# Altium schematic sheet lcd&key.SchDoc(lcd&key)
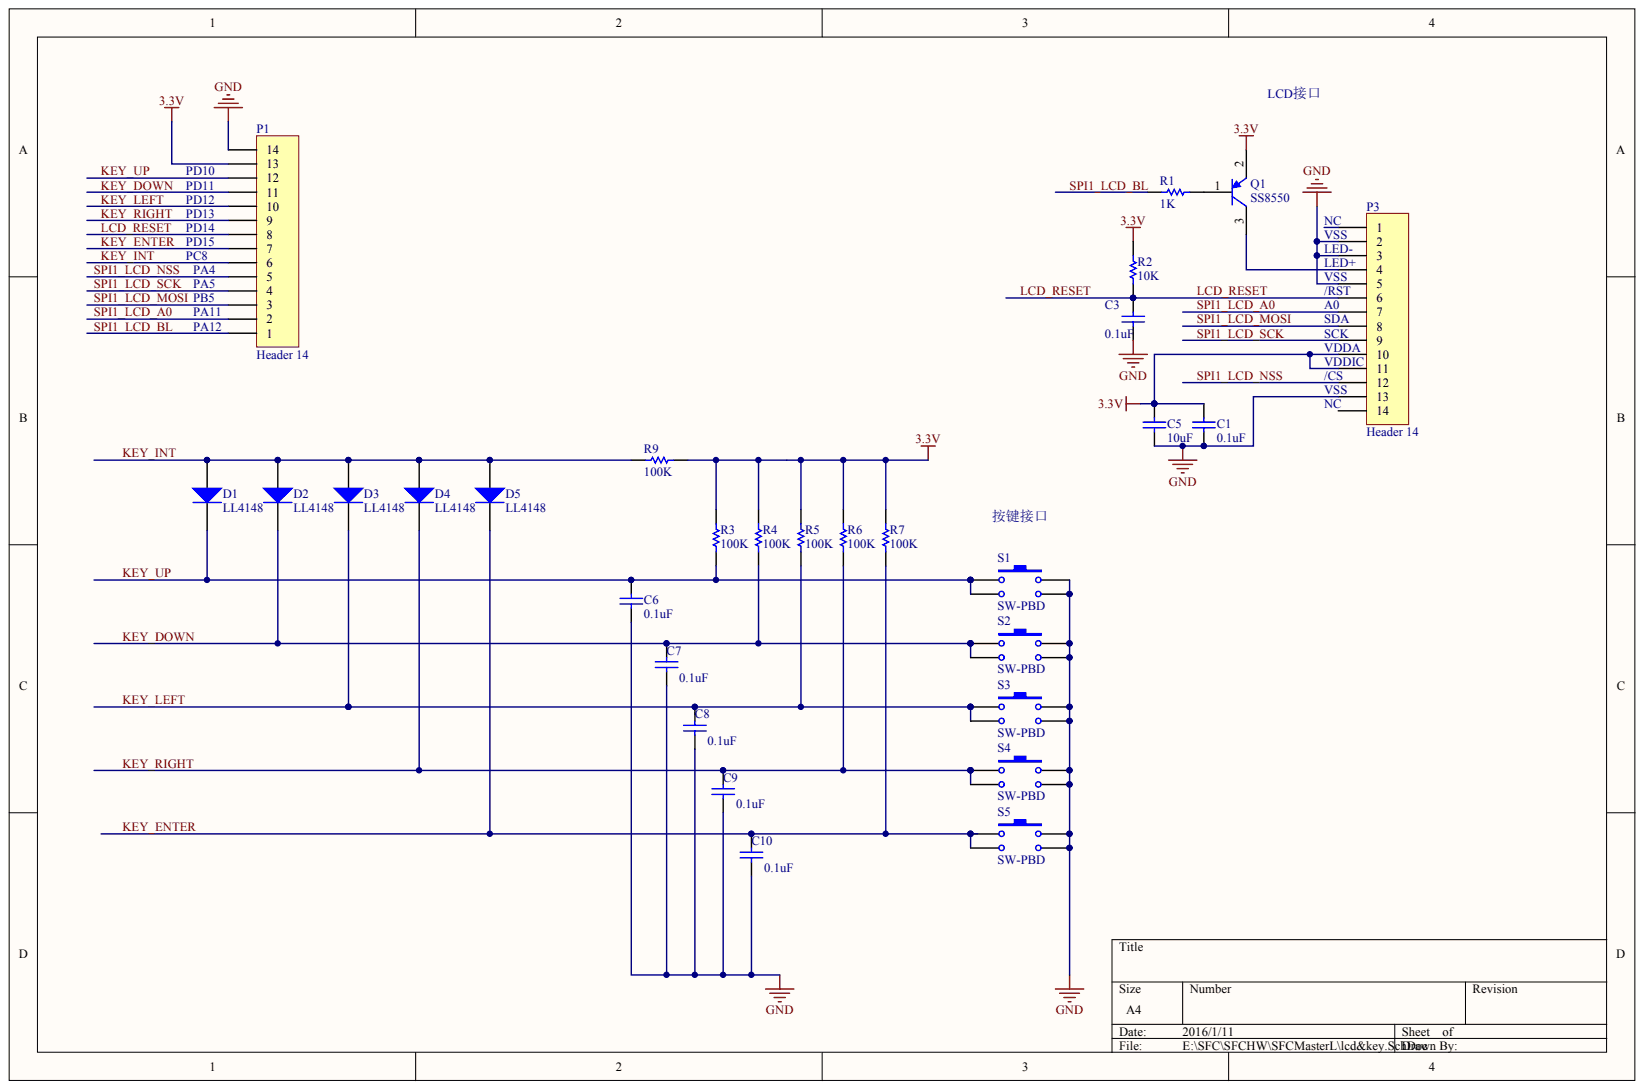
[diagram p0: lcd&key.SchDoc]

## Parts
C1  0.1uF
C3  0.1uF
C5  10uF
C6  0.1uF
C7  0.1uF
C8  0.1uF
C9  0.1uF
C10  0.1uF
D1  LL4148
D2  LL4148
D3  LL4148
D4  LL4148
D5  LL4148
P1  Header 14
P3  Header 14
Q1  SS8550
R1  1K
R2  10K
R3  100K
R4  100K
R5  100K
R6  100K
R7  100K
R9  100K
S1  SW-PBD
S2  SW-PBD
S3  SW-PBD
S4  SW-PBD
S5  SW-PBD

## Nets
3.3V: C1.2 C5.2 P1.13 P3.10 P3.11 Q1.2 R2.1 R3.2 R4.2 R5.2 R6.2 R7.2 R9.2
GND: C1.1 C3.2 C5.1 C6.2 C7.2 C8.2 C9.2 C10.2 P1.14 P3.2 P3.3 P3.5 P3.13 S1.3 S1.4 S2.3 S2.4 S3.3 S3.4 S4.3 S4.4 S5.3 S5.4
KEY_DOWN: C7.1 D2.2 P1.11 R4.1 S2.1 S2.2
KEY_ENTER: C10.1 D5.2 P1.7 R7.1 S5.1 S5.2
KEY_INT: D1.1 D2.1 D3.1 D4.1 D5.1 P1.6 R9.1
KEY_LEFT: C8.1 D3.2 P1.10 R5.1 S3.1 S3.2
KEY_RIGHT: C9.1 D4.2 P1.9 R6.1 S4.1 S4.2
KEY_UP: C6.1 D1.2 P1.12 R3.1 S1.1 S1.2
LCD_RESET: C3.1 P1.8 P3.6 R2.2
SPI1_LCD_A0: P1.2 P3.7
SPI1_LCD_BL: P1.1 R1.2
SPI1_LCD_MOSI: P1.3 P3.8
SPI1_LCD_NSS: P1.5 P3.12
SPI1_LCD_SCK: P1.4 P3.9
NetP3_4: P3.4 Q1.3
NetQ1_1: Q1.1 R1.1

Not connected: P3 1 14

## Library data of the parts
C1 C3 C5-C10 = (no symbol name): Value: 1nF; Published: 8-Jun-2000; LatestRevisionDate: 17-Jul-2002; LatestRevisionNote: Re-released for DXP Platform.; PackageReference: RAD-0.3; Publisher: Altium Limited
R1 R3-R7 R9 = (no symbol name): Value: 10K; Published: 8-Jun-2000; LatestRevisionDate: 17-Jul-2002; LatestRevisionNote: Re-released for DXP Platform.; PackageReference: AXIAL-0.3; Publisher: Altium Limited
D1-D5 P1 P3 Q1 R2 S1-S5 = (no symbol name): Publisher: Altium Limited
  D1-D5: Published: 8-Jun-2000; LatestRevisionDate: 17-Jul-2002; LatestRevisionNote: Re-released for DXP Platform.; DatasheetVersion: 1998; PackageVersion: Aug-1999; PackageReference: DO-41; Code_JEDEC: DO-204-AL; ComponentLink1Description: Manufacturer Link; ComponentLink1URL: http://www.fairchildsemi.com/; ComponentLink2Description: Datasheet; ComponentLink2URL: http://www.fairchildsemi.com/ds/1N%2F1N4001.pdf
  P1 P3: LatestRevisionDate: 17-Jul-2002; LatestRevisionNote: Re-released for DXP Platform.
  Q1: Published: 8-Jun-2000; LatestRevisionDate: 15-Jan-2003; LatestRevisionNote: PCB Footprint 'BCY-W3/D4.7' replaced; DatasheetVersion: 1997; PackageVersion: Sep-1998; PackageReference: TO-92A; Code_JEDEC: TO-92A; ComponentLink1Description: Manufacturer Link; ComponentLink1URL: http://www.fairchildsemi.com/; ComponentLink2Description: Datasheet; ComponentLink2URL: http://www.fairchildsemi.com/ds/2N%2F2N3906.pdf
  R2: Published: 8-Jun-2000; LatestRevisionDate: 17-Jul-2002; LatestRevisionNote: Re-released for DXP Platform.; PackageReference: AXIAL-0.3; Value: 10K
  S1-S5: Published: 24-Mar-1999; LatestRevisionDate: 17-Jul-2002; LatestRevisionNote: Re-released for DXP Platform.; PackageReference: SPST-2; PackageDescription: Switch; 2 Leads
